# Altium schematic sheet 2022_Badge.SchDoc
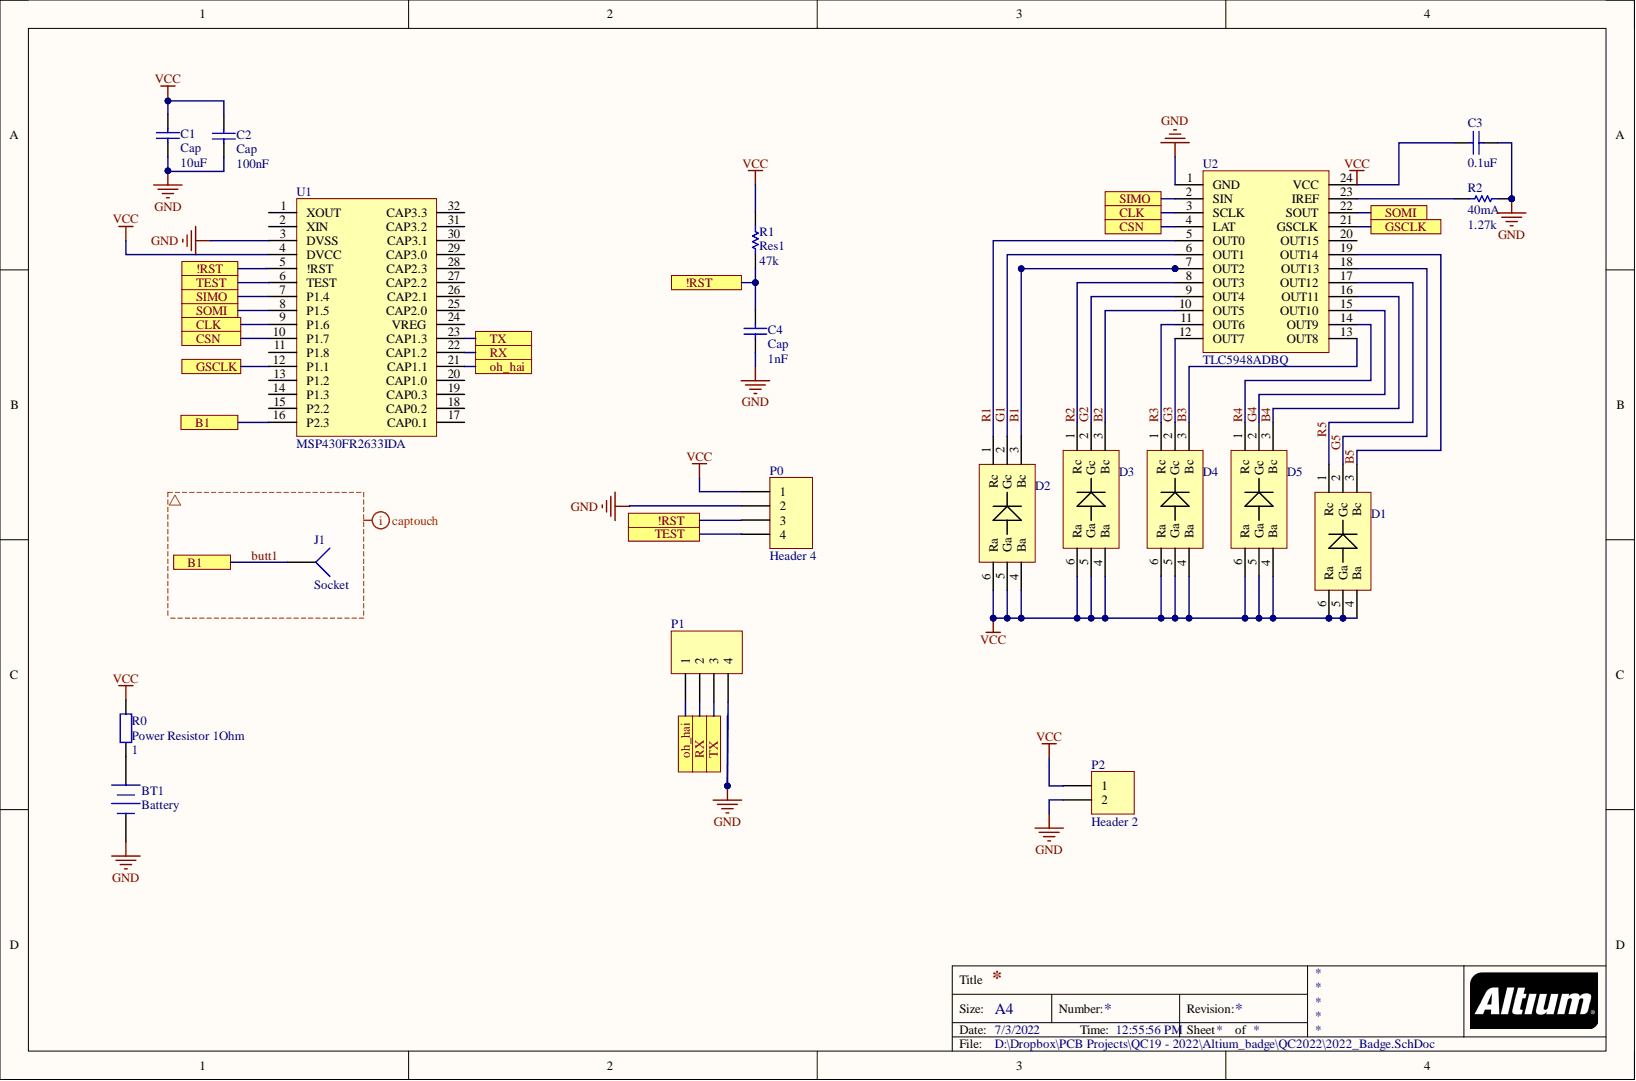
[diagram p0: 2022_Badge.SchDoc]

## Parts
BT1  Battery  [Keystone 1050]
C1  Cap  value 10uF  [CAPC1608(0603)]
C2  Cap  value 100nF  [CAPC1608(0603)]
C3  value 0.1uF  [CAPC1608(0603)]  (hidden comment: Cap)
C4  Cap  value 1nF  [CAPC1608(0603)]
D1  CREE LED  [SON300P420X255-6M]  (value not drawn)
D2  CREE LED  [SON300P420X255-6M]  (value not drawn)
D3  CREE LED  [SON300P420X255-6M]  (value not drawn)
D4  CREE LED  [SON300P420X255-6M]  (value not drawn)
D5  CREE LED  [SON300P420X255-6M]  (value not drawn)
J1  Socket  [captouch]
P0  Header 4  [1x4 2mm Header]
P1  Header 4  [4uppairing]  (value not drawn)
P2  Header 2  [HDR1X2]
R0  Power Resistor 1Ohm  value 1  [RESC5025(2010)]
R1  Res1  value 47k  [RESC1608(0603)]
R2  40mA  value 1.27k  [RESC1608(0603)]
U1  MSP430FR2633IDA  [TSOP65P810X120-32M]
U2  TLC5948ADBQ  [SOP64P600X175-24M]

## Ports
!RST, B1, CLK, CSN, GSCLK, oh_hai, RX, SIMO, SOMI, TEST, TX

## Nets
GND: BT1.2 C1.2 C2.1 C3.2 C4.1 P0.2 P1.4 P2.2 R2.2 U1.3(DVSS) U2.1(GND)
VCC: C1.1 C2.2 C3.1 D1.4(Ba) D1.5(Ga) D1.6(Ra) D2.4(Ba) D2.5(Ga) D2.6(Ra) D3.4(Ba) D3.5(Ga) D3.6(Ra) D4.4(Ba) D4.5(Ga) D4.6(Ra) D5.4(Ba) D5.5(Ga) D5.6(Ra) P0.1 P2.1 R0.2 R1.2 U1.4(DVCC) U2.24(VCC)
!RST: C4.2 P0.3 R1.1 U1.5(!RST) ; port !RST
B1: D2.3(Bc) U2.7(OUT2)
B2: D3.3(Bc) U2.10(OUT5)
B3: D4.3(Bc) U2.13(OUT8)
B4: D5.3(Bc) U2.16(OUT11)
B5: D1.3(Bc) U2.19(OUT14)
butt1: J1.1 U1.16(P2.3) ; port B1
CLK: U1.9(P1.6) U2.3(SCLK) ; port CLK
CSN: U1.10(P1.7) U2.4(LAT) ; port CSN
G1: D2.2(Gc) U2.6(OUT1)
G2: D3.2(Gc) U2.9(OUT4)
G3: D4.2(Gc) U2.12(OUT7)
G4: D5.2(Gc) U2.15(OUT10)
G5: D1.2(Gc) U2.18(OUT13)
GSCLK: U1.12(P1.1) U2.21(GSCLK) ; port GSCLK
oh_hai: P1.1 U1.21(CAP1.1) ; port oh_hai
R1: D2.1(Rc) U2.5(OUT0)
R2: D3.1(Rc) U2.8(OUT3)
R3: D4.1(Rc) U2.11(OUT6)
R4: D5.1(Rc) U2.14(OUT9)
R5: D1.1(Rc) U2.17(OUT12)
RX: P1.2 U1.22(CAP1.2) ; port RX
SIMO: U1.7(P1.4) U2.2(SIN) ; port SIMO
SOMI: U1.8(P1.5) U2.22(SOUT) ; port SOMI
TEST: P0.4 U1.6(TEST) ; port TEST
TX: P1.3 U1.23(CAP1.3) ; port TX
NetBT1_1: BT1.1 R0.1
NetR2_1: R2.1 U2.23(IREF)

Not connected: U1 1(XOUT) 2(XIN) 11(P1.8) 13(P1.2) 14(P1.3) 15(P2.2) 17(CAP0.1) 18(CAP0.2) 19(CAP0.3) 20(CAP1.0) 24(VREG) 25(CAP2.0) 26(CAP2.1) 27(CAP2.2) 28(CAP2.3) 29(CAP3.0) 30(CAP3.1) 31(CAP3.2) 32(CAP3.3); U2 20(OUT15)

## Library data of the parts
BT1 = Battery: Multicell Battery; Component Kind: Standard; LatestRevisionDate: 17-Jul-2002; LatestRevisionNote: Re-released for DXP Platform.; Library Name: Miscellaneous Devices.IntLib; PackageDescription: Battery; 2 Leads; PackageReference: BAT-2; Published: 24-Mar-1999; Publisher: Altium Limited
C1-C4 = Cap: Capacitor; Component Kind: Standard; LatestRevisionDate: 17-Jul-2002; LatestRevisionNote: Re-released for DXP Platform.; Library Name: Miscellaneous Devices.IntLib; PackageDescription: Radial Cap, Thru-Hole; 2 Leads; 0.3 in Pin Spacing; PackageReference: RAD-0.3; Published: 8-Jun-2000; Signal Integrity: Cap; Simulation: CAP; Publisher: Altium Limited
P0 P1 = Header 4: Header, 4-Pin; Component Kind: Standard; LatestRevisionDate: 17-Jul-2002; LatestRevisionNote: Re-released for DXP Platform.; Library Name: Miscellaneous Connectors.IntLib; Signal Integrity: Connector; Publisher: Altium Limited
P2 = Header 2: Header, 2-Pin; Component Kind: Standard; LatestRevisionDate: 17-Jul-2002; LatestRevisionNote: Re-released for DXP Platform.; Library Name: Miscellaneous Connectors.IntLib; Signal Integrity: Connector; Publisher: Altium Limited
R0 = Res2: Resistor; Component Kind: Standard; LatestRevisionDate: 17-Jul-2002; LatestRevisionNote: Re-released for DXP Platform.; Library Name: Miscellaneous Devices.IntLib; PackageReference: AXIAL-0.4; Published: 8-Jun-2000; Signal Integrity: Res; Simulation: RESISTOR; Publisher: Altium Limited
R1 R2 = Res1: Resistor; Component Kind: Standard; LatestRevisionDate: 17-Jul-2002; LatestRevisionNote: Re-released for DXP Platform.; Library Name: Miscellaneous Devices.IntLib; PackageDescription: Axial Device, Thru-Hole; 2 Leads; 0.3 in Pin Spacing; PackageReference: AXIAL-0.3; Published: 8-Jun-2000; Signal Integrity: Res; Simulation: RESISTOR; Publisher: Altium Limited
D1-D5 = CREE LED: Component Kind: Standard; Library Name: 2022.SchLib
J1 = Socket: Component Kind: Standard; Description: Socket; LatestRevisionDate: 17-Jul-2002; LatestRevisionNote: Re-released for DXP Platform.; Library Name: Miscellaneous Connectors.IntLib; Signal Integrity: Connector; Publisher: Altium Limited
U1 = MSP430FR2633IDA: Component Kind: Standard; Description: MSP430FR2633IDA; Library Name: 2022.SchLib
U2 = TLC5948ADBQ: Component Kind: Standard; Library Name: 2022.SchLib
